# Altium schematic sheet 12_PLCLK_100M.SchDoc
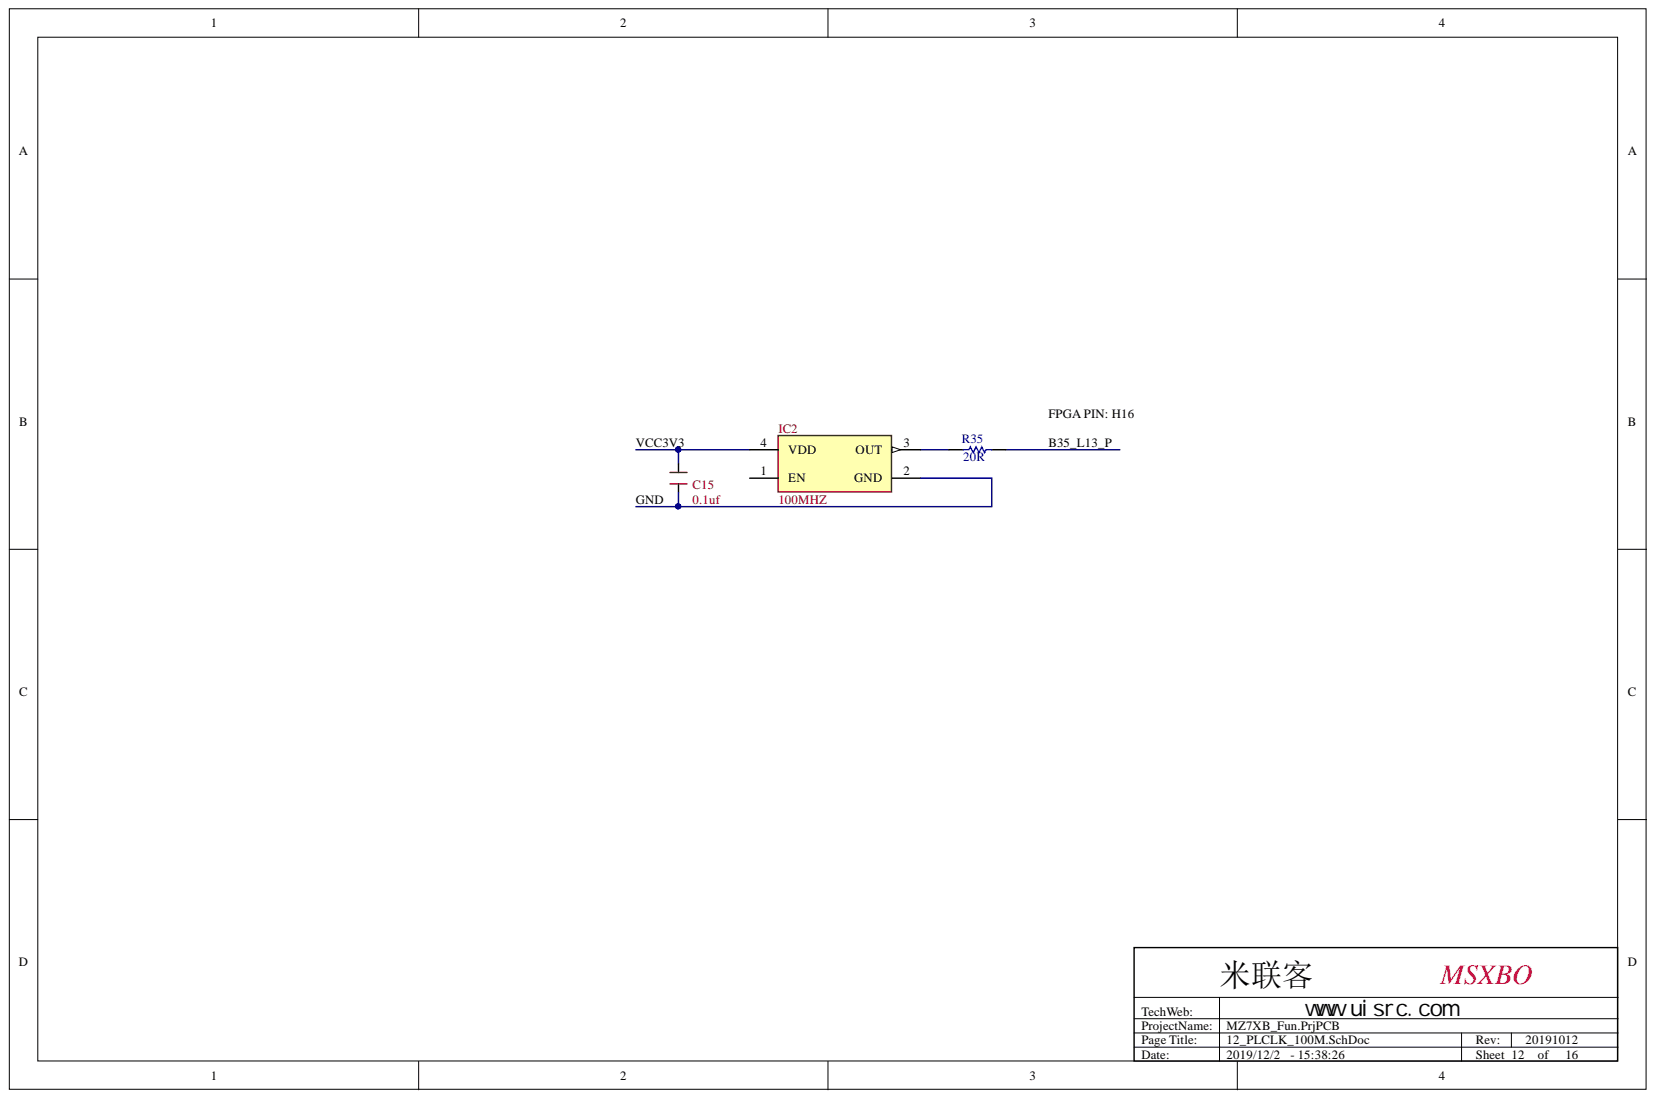
[diagram p0: 12_PLCLK_100M.SchDoc]

## Parts
C15  0.1uf  [CAP0402]
IC2  100MHZ  [3225]
R35  20R  [RES0402]

## Nets
B35_L13_P: R35.1
GND: C15.2 IC2.2(GND)
VCC3V3: C15.1 IC2.4(VDD)
NetIC2_3: IC2.3(OUT) R35.2

Not connected: IC2 1(EN)

## Library data of the parts
C15 = CAPACITOR: Generic Capacitor; Value: 10nF; Component Kind: Standard; Library Name: Digilent.IntLib
IC2 = FXO-HC5: 3.3V HCMOS Oscillator; Manufacturer: Fox Electronics; Value: 26MHz; Component Kind: Standard; Library Name: Digilent.IntLib
R35 = Res: 1K; Component Kind: Standard; Library Name: jinrylib.IntLib
